# Altium schematic sheet RA_Local_Promotion_Board_1.SchDoc(RA_Local_Promotion_Board_1)
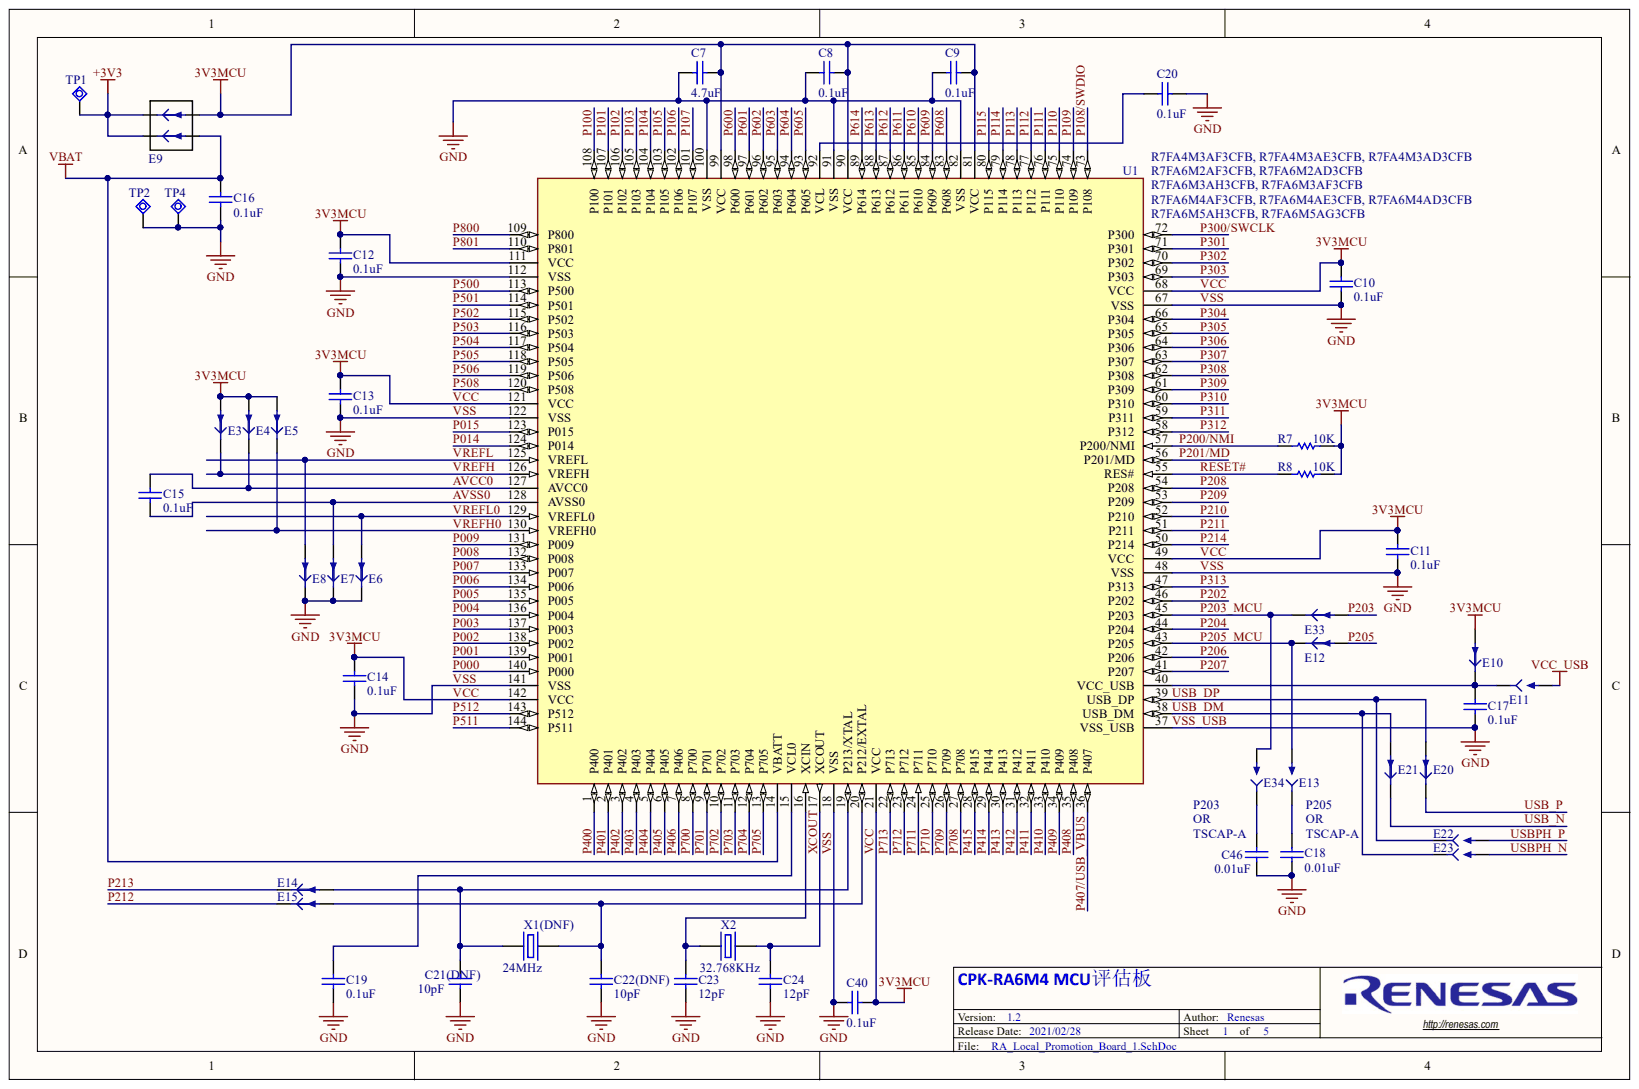
[diagram p0: RA_Local_Promotion_Board_1.SchDoc]

## Parts
C7  4.7uF  [CAPC0603-01]
C8  0.1uF  [CAPC0603-01]
C9  0.1uF  [CAPC0603-01]
C10  0.1uF  [CAPC0603-01]
C11  0.1uF  [CAPC0603-01]
C12  0.1uF  [CAPC0603-01]
C13  0.1uF  [CAPC0603-01]
C14  0.1uF  [CAPC0603-01]
C15  0.1uF  [CAPC0603-01]
C16  0.1uF  [CAPC0603-01]
C17  0.1uF  [CAPC0603-01]
C18  0.1uF  Capacitance: 0.01uF  [CAPC0603-01]
C19  0.1uF  [CAPC0603-01]
C20  0.1uF  [CAPC0603-01]
C21(DNF)  10pF  [CAPC0603-01]
C22(DNF)  10pF  [CAPC0603-01]
C23  12pF  [CAPC0603-01]
C24  12pF  [CAPC0603-01]
C40  0.1uF  [CAPC0603-01]
C46  0.1uF  Capacitance: 0.01uF  [CAPC0603-01]
E3  Jumper Trace Cut  [E_PadsTraceCut0805]  (value not drawn)  (no BOM)
E4  Jumper Trace Cut  [E_PadsTraceCut0805]  (value not drawn)  (no BOM)
E5  Jumper Trace Cut  [E_PadsTraceCut0805]  (value not drawn)  (no BOM)
E6  Jumper Trace Cut  [E_PadsTraceCut0805]  (value not drawn)  (no BOM)
E7  Jumper Trace Cut  [E_PadsTraceCut0805]  (value not drawn)  (no BOM)
E8  Jumper Trace Cut  [E_PadsTraceCut0805]  (value not drawn)  (no BOM)
E9  Dual Jumper Trace Cut  [CON-4A - B]  (value not drawn)  (no BOM)
E10  Jumper Trace Cut  [E_PadsTraceCut0805]  (value not drawn)  (no BOM)
E11  Jumper Solder Bridging  [E_PadsSplit0805]  (value not drawn)  (no BOM)
E12  Jumper Trace Cut  [E_PadsTraceCut0805]  (value not drawn)  (no BOM)
E13  Jumper Solder Bridging  [E_PadsSplit0805]  (value not drawn)  (no BOM)
E14  Jumper Trace Cut  [E_PadsTraceCut0805]  (value not drawn)  (no BOM)
E15  Jumper Trace Cut  [E_PadsTraceCut0805]  (value not drawn)  (no BOM)
E20  Jumper Trace Cut  [E_PadsTraceCut0805]  (value not drawn)  (no BOM)
E21  Jumper Trace Cut  [E_PadsTraceCut0805]  (value not drawn)  (no BOM)
E22  Jumper Solder Bridging  [E_PadsSplit0805]  (value not drawn)  (no BOM)
E23  Jumper Solder Bridging  [E_PadsSplit0805]  (value not drawn)  (no BOM)
E33  Jumper Trace Cut  [E_PadsTraceCut0805]  (value not drawn)  (no BOM)
E34  Jumper Solder Bridging  [E_PadsSplit0805]  (value not drawn)  (no BOM)
R7  10K  [RESC0603-01]
R8  10K  [RESC0603-01]
TP1  Test Point  [TP A - Red]  (value not drawn)
TP2  Test Point  [TP A - BLK]  (value not drawn)
TP4  Test Point  [TP A - BLK]  (value not drawn)
U1  RA6M2  [RENESAS_PLQP0144KA-B_N]  (value not drawn)
X1(DNF)  24MHz  [OSC_5032]
X2  Frequency: 32.768KHz  [ABRACON_ABS07]  (hidden comment: 32.769KHz)

## Nets
+3V3: E9.1 E9.2 TP1.1
VBAT: C16.1 E9.4 U1.14(VBATT)
VCC_USB: E11.2
AVCC0: C15.1 E4.1 U1.127(AVCC0)
AVSS0: C15.2 E7.2 U1.128(AVSS0)
P000: U1.140(P000)
P001: U1.139(P001)
P002: U1.138(P002)
P003: U1.137(P003)
P004: U1.136(P004)
P005: U1.135(P005)
P006: U1.134(P006)
P007: U1.133(P007)
P008: U1.132(P008)
P009: U1.131(P009)
P014: U1.124(P014)
P015: U1.123(P015)
P100: U1.108(P100)
P101: U1.107(P101)
P102: U1.106(P102)
P103: U1.105(P103)
P104: U1.104(P104)
P105: U1.103(P105)
P106: U1.102(P106)
P107: U1.101(P107)
P108/SWDIO: U1.73(P108)
P109: U1.74(P109)
P110: U1.75(P110)
P111: U1.76(P111)
P112: U1.77(P112)
P113: U1.78(P113)
P114: U1.79(P114)
P115: U1.80(P115)
P200/NMI: R7.1 U1.57(P200/NMI)
P201/MD: U1.56(P201/MD)
P202: U1.46(P202)
P203: E33.2
P203_MCU: E33.1 E34.2 U1.45(P203)
P204: U1.44(P204)
P205: E12.2
P205_MCU: E12.1 E13.2 U1.43(P205)
P206: U1.42(P206)
P207: U1.41(P207)
P208: U1.54(P208)
P209: U1.53(P209)
P210: U1.52(P210)
P211: U1.51(P211)
P212: E15.1
P213: E14.1
P214: U1.50(P214)
P300/SWCLK: U1.72(P300)
P301: U1.71(P301)
P302: U1.70(P302)
P303: U1.69(P303)
P304: U1.66(P304)
P305: U1.65(P305)
P306: U1.64(P306)
P307: U1.63(P307)
P308: U1.62(P308)
P309: U1.61(P309)
P310: U1.60(P310)
P311: U1.59(P311)
P312: U1.58(P312)
P313: U1.47(P313)
P400: U1.1(P400)
P401: U1.2(P401)
P402: U1.3(P402)
P403: U1.4(P403)
P404: U1.5(P404)
P405: U1.6(P405)
P406: U1.7(P406)
P407/USB_VBUS: U1.36(P407)
P408: U1.35(P408)
P409: U1.34(P409)
P410: U1.33(P410)
P411: U1.32(P411)
P412: U1.31(P412)
P413: U1.30(P413)
P414: U1.29(P414)
P415: U1.28(P415)
P500: U1.113(P500)
P501: U1.114(P501)
P502: U1.115(P502)
P503: U1.116(P503)
P504: U1.117(P504)
P505: U1.118(P505)
P506: U1.119(P506)
P508: U1.120(P508)
P511: U1.144(P511)
P512: U1.143(P512)
P600: U1.98(P600)
P601: U1.97(P601)
P602: U1.96(P602)
P603: U1.95(P603)
P604: U1.94(P604)
P605: U1.93(P605)
P608: U1.83(P608)
P609: U1.84(P609)
P610: U1.85(P610)
P611: U1.86(P611)
P612: U1.87(P612)
P613: U1.88(P613)
P614: U1.89(P614)
P700: U1.8(P700)
P701: U1.9(P701)
P702: U1.10(P702)
P703: U1.11(P703)
P704: U1.12(P704)
P705: U1.13(P705)
P708: U1.27(P708)
P709: U1.26(P709)
P710: U1.25(P710)
P711: U1.24(P711)
P712: U1.23(P712)
P713: U1.22(P713)
P800: U1.109(P800)
P801: U1.110(P801)
RESET#: R8.1 U1.55(RES#)
USB_DM: E21.2 E23.1 U1.38(USB_DM)
USB_DP: E20.2 E22.1 U1.39(USB_DP)
USB_N: E21.1
USB_P: E20.1
USBPH_N: E23.2
USBPH_P: E22.2
VCC: C7.2 C8.2 C9.2 C10.1 C11.1 C12.1 C13.1 C14.2 C40.1 E3.2 E4.2 E5.2 E9.3 E10.2 R7.2 R8.2 U1.21(VCC) U1.49(VCC) U1.68(VCC) U1.81(VCC) U1.90(VCC) U1.99(VCC) U1.111(VCC) U1.121(VCC) U1.142(VCC)
VREFH: E3.1 U1.126(VREFH)
VREFH0: E5.1 U1.130(VREFH0)
VREFL: E8.2 U1.125(VREFL)
VREFL0: E6.2 U1.129(VREFL0)
VSS: C7.1 C8.1 C9.1 C10.2 C11.2 C12.2 C13.2 C14.1 C16.2 C17.2 C18.2 C19.1 C20.1 C21(DNF).1 C22(DNF).1 C23.1 C24.1 C40.2 C46.2 E6.1 E7.1 E8.1 TP2.1 TP4.1 U1.18(VSS) U1.37(VSS_USB) U1.48(VSS) U1.67(VSS) U1.82(VSS) U1.91(VSS) U1.100(VSS) U1.112(VSS) U1.122(VSS) U1.141(VSS)
XCOUT: C24.2 U1.17(XCOUT) X2.2
NetC17_1: C17.1 E10.1 E11.1 U1.40(VCC_USB)
NetC18_1: C18.1 E13.1
NetC19_2: C19.2 U1.15(VCL0)
NetC20_2: C20.2 U1.92(VCL)
NetC21(DNF)_2: C21(DNF).2 E14.2 U1.19(P213/XTAL) X1(DNF).1
NetC22(DNF)_2: C22(DNF).2 E15.2 U1.20(P212/EXTAL) X1(DNF).2
NetC23_2: C23.2 U1.16(XCIN) X2.1
NetC46_1: C46.1 E34.1

## Library data of the parts
C7 = CAP-0603-4.7uF: Ceramic Chip Capacitor 0603 4.7uF 6.3V; Manufacturer: TDK; Mfg Part Number: CGA3E1X5R0J475K080AC; Dialectric: X5R; Tolerance: 10%; Capacitance: 4.7uF; Component Kind: Standard; Package: 0603; Voltage: 6.3V; Library Name: Synergy.SchLib
C8-C20 C40 C46 = CAP-0603-0.1uF: Ceramic Chip Capacitor 0603 0.1uF 50V; Manufacturer: MURATA; Mfg Part Number: GCM188L81H104KA57D; Dialectric: X8L; Tolerance: 10%; Component Kind: Standard; Library Name: New Com.SchLib; Package: 0603; Voltage: 50V
  C8-C17 C19 C20 C40: Capacitance: 0.1uF
C21(DNF) C22(DNF) = CAP-0603-10pF: Ceramic Chip Capacitor 0603 10pF 50V; Manufacturer: Murata; Mfg Part Number: GRM1885C1H100JA01J; Dialectric: COG; Tolerance: 5%; Capacitance: 10pF; Component Kind: Standard; Library Name: New Com.SchLib; Package: 0603; Voltage: 50V
C23 C24 = CAP-0603-12pF: Ceramic Chip Capacitor 0603 12pF 50V; Manufacturer: Murata; Mfg Part Number: GRM1885C1H120JA01D; Dialectric: COG; Tolerance: 5%; Capacitance: 12pF; Component Kind: Standard; Library Name: New Com.SchLib; Package: 0603; Voltage: 50V
E3-E10 E12 E14 E15 E20 E21 E33 = E_JmpTraceCut: Pads on PCB with Trace Between for Cutting to isolate; Part Type: Jumper Trace Cut
  E9: Library Name: New Com.SchLib
  E3-E8 E10 E12 E14 E15 E20 E21 E33: Library Name: Synergy.SchLib
E11 E13 E22 E23 E34 = E_JmpSldrBridge: Pads on PCB with Narrow Gap to allow Solder Bridging; Part Type: Jumper Solder Bridging; Library Name: Synergy.SchLib
R7 R8 = RES-0603-10K: Chip Resistor Thick Film 0603 10K 1% 1/10W; Manufacturer: YAGEO; Mfg Part Number: RC0603FR-0710KL; Component Kind: Standard; Library Name: New Com.SchLib; Package: 0603; Power: 1/10W; Resistance: 10K; Tolerance: 1%
TP1 TP2 TP4 = CON-Test Point: Component Kind: Standard; Description: Test Point; Library Name: Synergy.SchLib
U1 = ICN-RA6M2-LQFP144: Renesas RA6M2 ARM Cortex M4 120MHz MCU LQFP144; Mfg Part Number: R7FA6M2AD3CFB; Architecture: ARM Ctx M4; CAN: 1; FLASH CODE: 1MB; FLASH DATA: 32KB; RAM: 384KB; Component Kind: Standard; Library Name: New Com.SchLib; Manufacturer: Renesas; Max Clock Speed: 120MHz; Package: LQFP-144
X1(DNF) = XTL-32.769KHz SMT: Crystal 24MHz SMT; Manufacturer: Abracon; Mfg Part Number: ABS07-24MHz-T; Freq Stability: +/- 20ppm; Load Capacitance: 12.5pF; Component Kind: Standard; Frequency: 24MHz; Library Name: Synergy.SchLib
X2 = XTL-32.769KHz SMT: Crystal 32.769KHz 12.5pF SMT; Manufacturer: Abracon; Mfg Part Number: ABS07-32.768KHZ-T; Freq Stability: +/- 20ppm; Component Kind: Standard; Load Capacitance: 12.5pF; Library Name: Synergy.SchLib
